# Altium schematic sheet Ctrl Sist de seguridad SCH.SchDoc
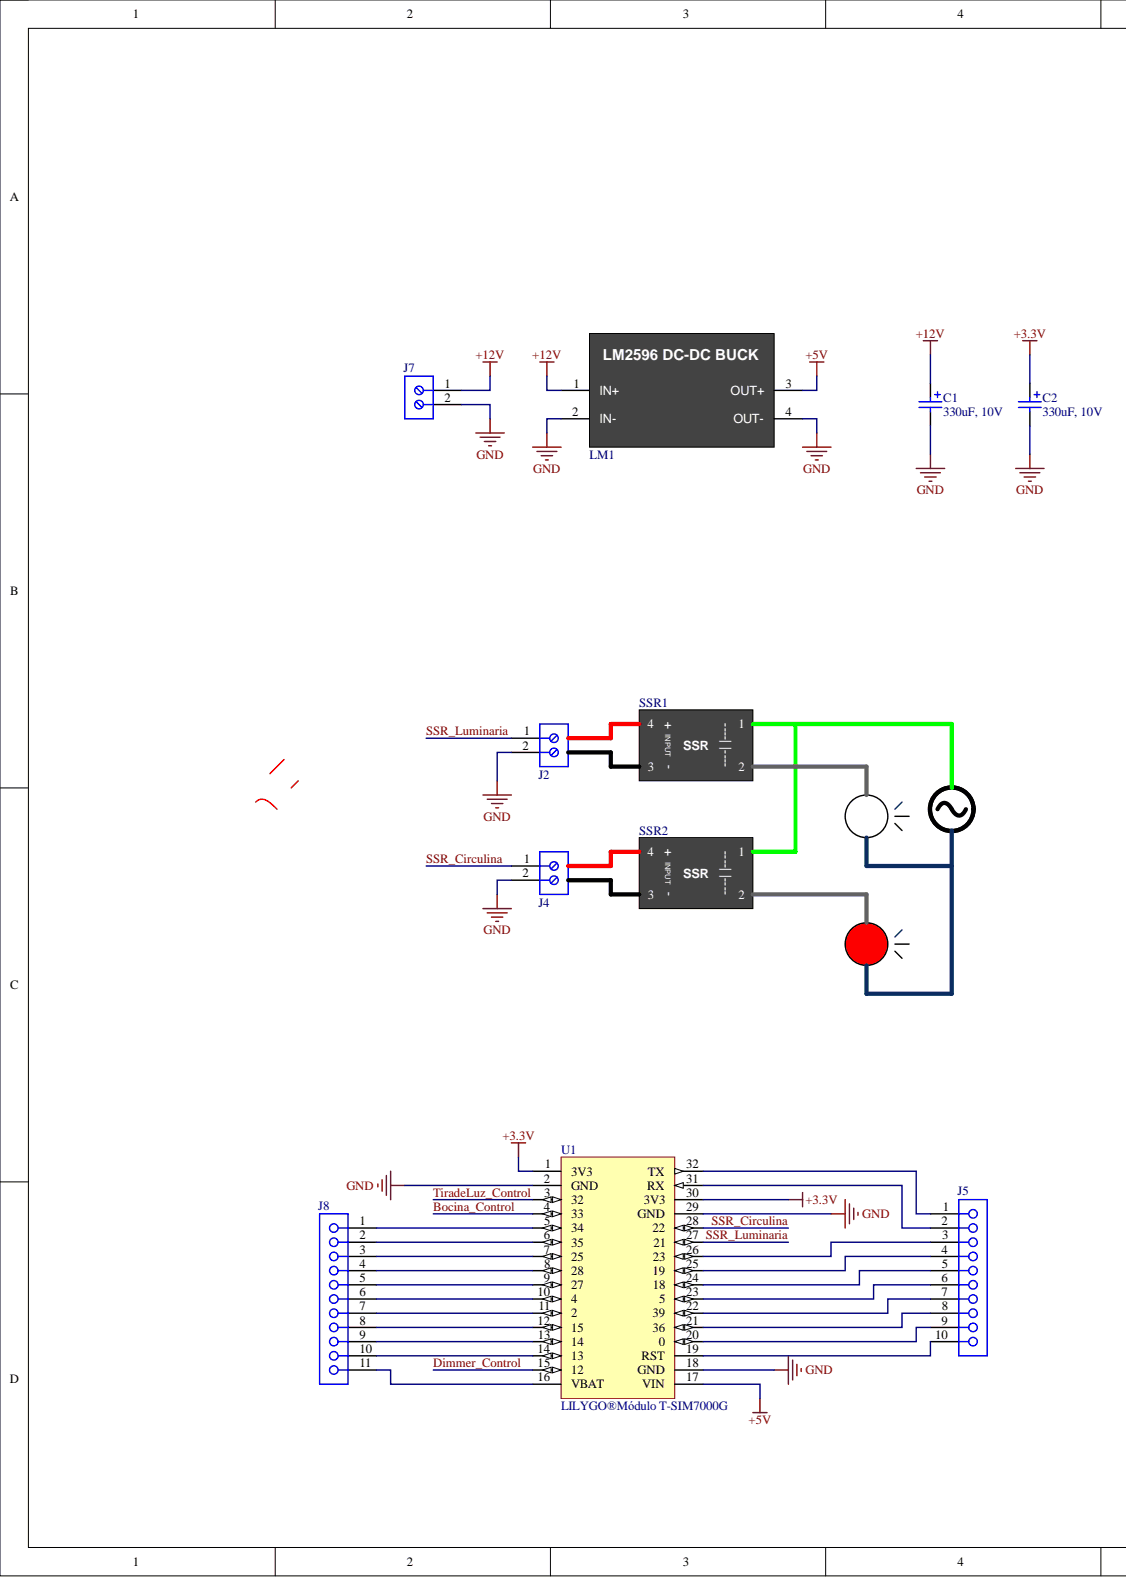
[diagram p0: Ctrl Sist de seguridad SCH.SchDoc, left]
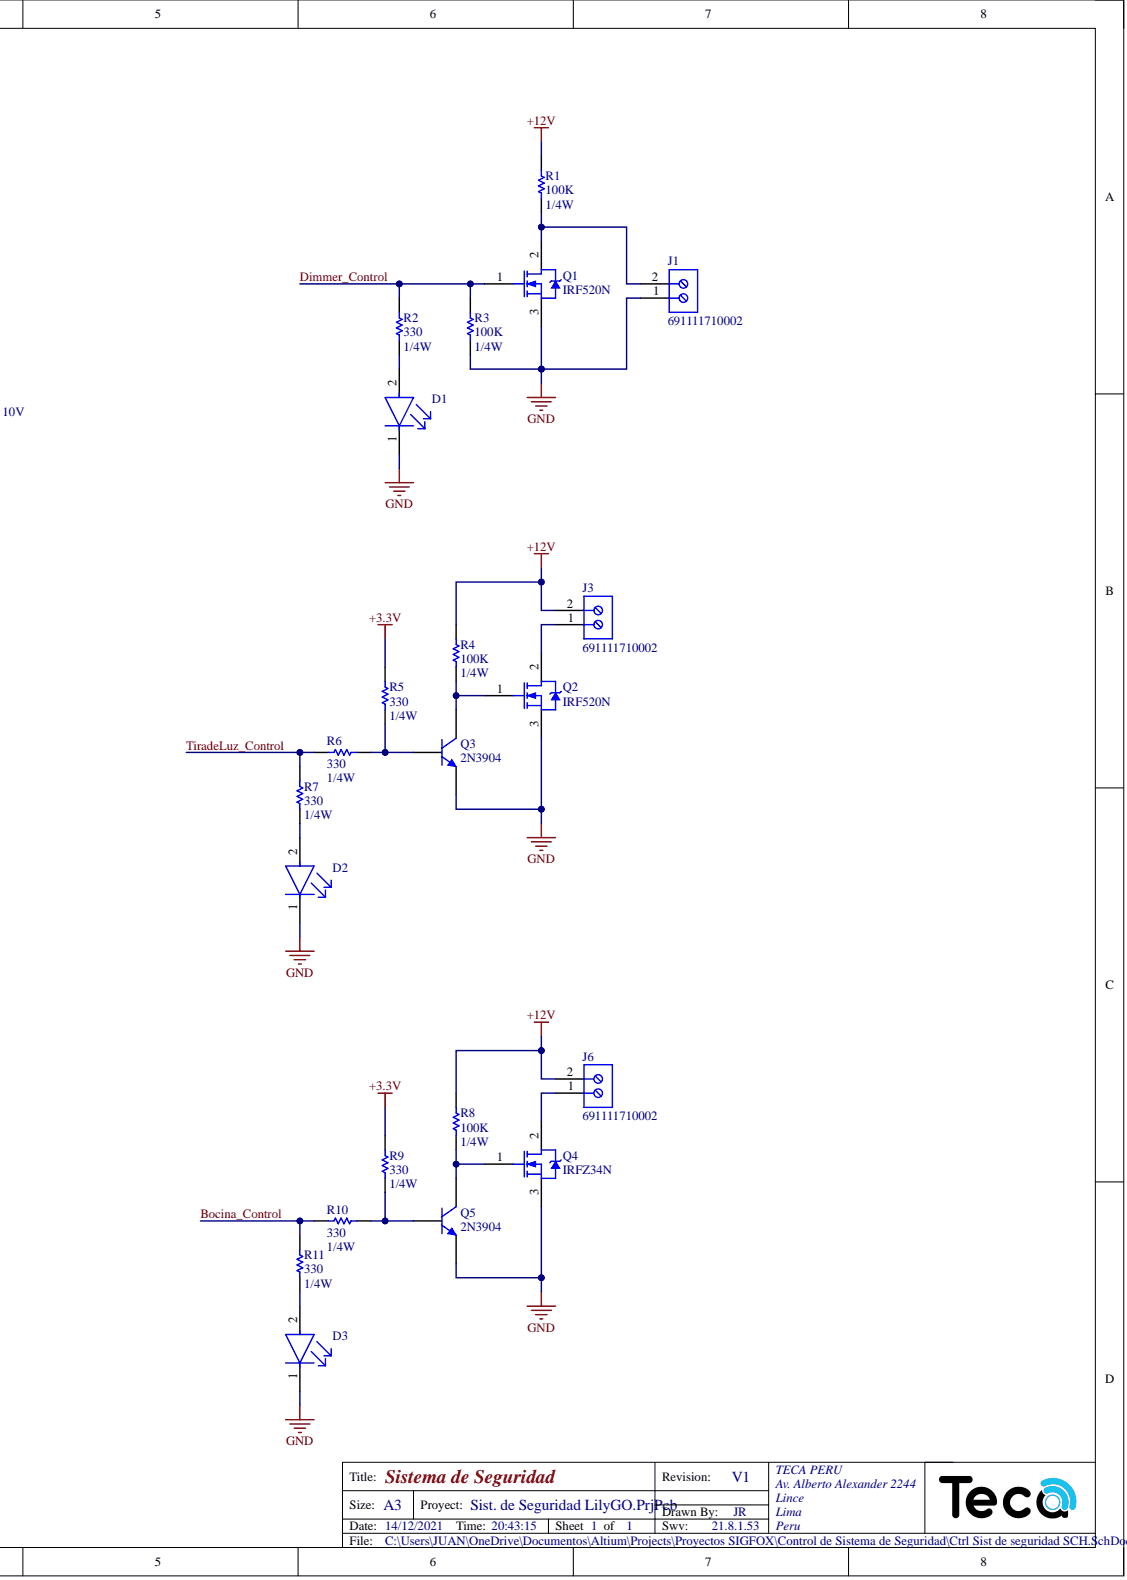
[diagram p1: Ctrl Sist de seguridad SCH.SchDoc, right]

## Parts
C1  330uF, 10V  [WCAP-ATLI_D8H11.5]
C2  330uF, 10V  [WCAP-ATLI_D8H11.5]
D1  HLMP-4700  [FP-HLMP-4700-MFG]  (value not drawn)
D2  HLMP-4700  [FP-HLMP-4700-MFG]  (value not drawn)
D3  HLMP-4700  [FP-HLMP-4700-MFG]  (value not drawn)
J1  691111710002  [691111710002]
J2  691111710002  [691111710002]
J3  691111710002  [691111710002]
J4  691111710002  [691111710002]
J5  61301011821  [61301011821]  (value not drawn)
J6  691111710002  [691111710002]
J7  691111710002  [691111710002]
J8  61301111821  [61301111821]  (value not drawn)
LM1  LM2596?  [LM2596]
Q1  IRF520N  [INTR-TO-220AB-3]
Q2  IRF520N  [INTR-TO-220AB-3]
Q3  2N3904  [TO-92A]
Q4  IRFZ34N  [INTR-TO-220AB-3]
Q5  2N3904  [TO-92A]
R1  Power Rating: 1/4W  Resistance: 100K  [RESISTOR 1/4W - 10mm]  (hidden comment: Resistor 1/4W)
R2  Power Rating: 1/4W  [RESISTOR 1/4W - 10mm]  (hidden comment: Resistor 1/4W)
R3  Power Rating: 1/4W  Resistance: 100K  [RESISTOR 1/4W - 10mm]  (hidden comment: Resistor 1/4W)
R4  Power Rating: 1/4W  Resistance: 100K  [RESISTOR 1/4W - 10mm]  (hidden comment: Resistor 1/4W)
R5  Power Rating: 1/4W  [RESISTOR 1/4W - 10mm]  (hidden comment: Resistor 1/4W)
R6  Resistor 1/4W  [RESISTOR 1/4W - 10mm]  (value not drawn)
R7  Power Rating: 1/4W  [RESISTOR 1/4W - 10mm]  (hidden comment: Resistor 1/4W)
R8  Power Rating: 1/4W  Resistance: 100K  [RESISTOR 1/4W - 10mm]  (hidden comment: Resistor 1/4W)
R9  Power Rating: 1/4W  [RESISTOR 1/4W - 10mm]  (hidden comment: Resistor 1/4W)
R10  Resistor 1/4W  [RESISTOR 1/4W - 10mm]  (value not drawn)
R11  Power Rating: 1/4W  [RESISTOR 1/4W - 10mm]  (hidden comment: Resistor 1/4W)
SSR1  SSR  [SSR Generic]
SSR2  SSR  [SSR Generic]
U1  LILYGO®Módulo T-SIM7000G  [LILYGO T-SIM7000G]

## Nets
+3.3V: C2.1 R5.2 R9.2 U1.1(3V3) U1.30(3V3)
+5V: LM1.3(OUT+) U1.17(VIN)
+12V: C1.1 J3.2 J6.2 J7.1 LM1.1(IN+) R1.2 R4.2 R8.2
GND: C1.2 C2.2 D1.1 D2.1 D3.1 J1.1 J2.2 J4.2 J7.2 LM1.2(IN-) LM1.4(OUT-) Q1.3 Q2.3 Q3.1 Q4.3 Q5.1 R3.1 U1.2(GND) U1.18(GND) U1.29(GND)
Bocina_Control: R10.2 R11.1 U1.4
Dimmer_Control: Q1.1 R2.2 R3.2 U1.15
SSR_Circulina: J4.1 U1.28
SSR_Luminaria: J2.1 U1.27
TiradeLuz_Control: R6.2 R7.1 U1.3
NetD1_2: D1.2 R2.1
NetD2_2: D2.2 R7.2
NetD3_2: D3.2 R11.2
NetJ1_2: J1.2 Q1.2 R1.1
NetJ3_1: J3.1 Q2.2
NetJ5_1: J5.1 U1.32(TX)
NetJ5_2: J5.2 U1.31(RX)
NetJ5_3: J5.3 U1.26
NetJ5_4: J5.4 U1.25
NetJ5_5: J5.5 U1.24
NetJ5_6: J5.6 U1.23
NetJ5_7: J5.7 U1.22
NetJ5_8: J5.8 U1.21
NetJ5_9: J5.9 U1.20
NetJ5_10: J5.10 U1.19(RST)
NetJ6_1: J6.1 Q4.2
NetJ8_1: J8.1 U1.5
NetJ8_2: J8.2 U1.6
NetJ8_3: J8.3 U1.7
NetJ8_4: J8.4 U1.8
NetJ8_5: J8.5 U1.9
NetJ8_6: J8.6 U1.10
NetJ8_7: J8.7 U1.11
NetJ8_8: J8.8 U1.12
NetJ8_9: J8.9 U1.13
NetJ8_10: J8.10 U1.14
NetJ8_11: J8.11 U1.16(VBAT)
NetQ2_1: Q2.1 Q3.3 R4.1
NetQ3_2: Q3.2 R5.1 R6.1
NetQ4_1: Q4.1 Q5.3 R8.1
NetQ5_2: Q5.2 R9.1 R10.1

## Library data of the parts
C1 C2 = 860080274009: WCAP-ATLI Aluminum Electrolytic Capacitor, Radial, THT, D8xH11.5mm, 330uF, 10V; Manufacturer: Wurth Elektronik; Capacitance Tolerance: +/-20%; Dissipation Factor: 14%; Endurance: 3000h; Leakage Current: 33uA; Rated Voltage: 10VDC; Ripple Current: 540mA; Technical Reference: ATEE115331M010DSPA7C000; Capacitance: 330uF; Component Kind: Standard; ComponentLink1Description: Manufacturer; ComponentLink1URL: http://www.we-online.de; ComponentLink2Description: Datasheet; ComponentLink2URL: https://www.we-online.com/catalog/datasheet/860080274009.pdf; Diameter: 8mm; Height: 11.5mm; Manufacturer Part Number: 860080274009; Mounting Technology: THT; Operating Temperature: -55 to +105degC; Packaging: Ammo Pack; Published Date: October 2020; Library Name: WCAP-ATLI Aluminion Electrolytic Capacitors.IntLib
D1-D3 = CMP-18399-000008-1: LED RED DIFFUSED T-1 3/4 T/H; Manufacturer: Broadcom; Forward Current: 2mA; Forward Voltage: 1.7V; Led Orientation: Straight; Luminous Intensity: 2.3mcd; Peak Emmision Wavelength: 635nm; Power Dissipation: 14mW; Reverse Voltage: 5V; Viewing Angle: 50°; Wavelength: 626nm; Automotive: No; Category: Diode; Colour: Red; Component Kind: Standard; ComponentLink2Description: Datasheet; ComponentLink2URL: https://docs.broadcom.com/docs/AV02-1557EN; Device Class L1: Optoelectronics; Device Class L2: LEDs; Device Class L3: unset; Digikey Description: LED RED DIFFUSED T-1 3/4 T/H; Lead Free: Yes; Library Name: Altium Content Vault; Manufacturer Part Number: HLMP-4700; Mouser Description: LED; Red; 2.3mcd; 0.24In.Dia.; T-1-3/4; 500mA; 1.7V; 635nm; 50deg; 5V; 24mW; -55degC;; Octopart: https://octopart.com/hlmp-4700-broadcom-71130526; Package: HLMP-4700; RoHS: TRUE; Temperature: -40°C to +100°C; Temperature Range High: +100°C; Temperature Range Low: -40°C
J1-J4 J6 J7 = 691111710002: WR-TBL Serie 111 Horizontal Entry Modular, Pressure Clamp, THT, pitch 5mm, 2p; Manufacturer: Wurth Elektronik; Modularity: Yes; PCB/Cable/Panel: PCB; Pin Number: 2; Rated Current [UL]: 15A; Working Voltage [UL]: 250VAC; Application: Pressure Clamp; Component Kind: Standard; ComponentLink1Description: Manufacturer; ComponentLink1URL: http://www.we-online.de; ComponentLink2Description: Datasheet; ComponentLink2URL: https://katalog.we-online.de/em/datasheet/691111710002.pdf; Manufacturer Part Number: 691111710002; Mounting Technology: THT; Operating Temperature: -40 to +105degC; Packaging: Box; Pitch: 5mm; Published Date: Feburary 2021; Type: Horizontal; Library Name: WR-TBL Terminal Blocks.IntLib
J5 = 61301011821: WR-PHD Socket Header, THT, pitch 2.54mm, Single Row, Vertical, 10p; Manufacturer: Wurth Elektronik; Contact Resistance: 20mOhm; Pin Number: 10; Rated Current: 3A; Withstanding Voltage: 500VAC; Working Voltage: 250VAC; Component Kind: Standard; ComponentLink1Description: Manufacturer; ComponentLink1URL: http://www.we-online.de; ComponentLink2Description: Datasheet; ComponentLink2URL: https://www.we-online.com/catalog/datasheet/61301011821.pdf; Gender: Socket Header; Manufacturer Part Number: 61301011821; Mounting Technology: THT; Operating Temperature: -40 to +125degC; Orientation: Vertical; Packaging: Tray; Pitch: 2.54mm; Published Date: May 2020; Row Number: Single; Library Name: WE-WR PHD Conectors.IntLib
J8 = 61301111821: WR-PHD Socket Header, THT, pitch 2.54mm, Single Row, Vertical, 11p; Manufacturer: Wurth Elektronik; Contact Resistance: 20mOhm; Pin Number: 11; Rated Current: 3A; Withstanding Voltage: 500VAC; Working Voltage: 250VAC; Component Kind: Standard; ComponentLink1Description: Manufacturer; ComponentLink1URL: http://www.we-online.de; ComponentLink2Description: Datasheet; ComponentLink2URL: https://www.we-online.com/catalog/datasheet/61301111821.pdf; Gender: Socket Header; Manufacturer Part Number: 61301111821; Mounting Technology: THT; Operating Temperature: -40 to +125degC; Orientation: Vertical; Packaging: Tray; Pitch: 2.54mm; Published Date: May 2020; Row Number: Single; Library Name: WE-WR PHD Conectors.IntLib
LM1 = LM2596?: Módulo de DC-DC LM2596, regulador de convertidor Buck de reducción ajustable, VIN: 3,2 V-40V ; VOUT: 1,25 V-35V; Component Kind: Standard; Library Name: Modulos.IntLib
Q1 Q2 Q4 = CMP-2100-03647-1: HEXFET(R) Power MOSFET, 100 V, 9.7 A, 0.2 Ohm, -55 to 175 degC, 3-Pin FM (TO-220AB), RoHS, Tube; Manufacturer: International Rectifier; Continuous Drain Current (ID): 9.7A; Drain to Source Breakdown Voltage: 100V; Drain to Source Resistance: 200mOhm; Element Configuration: Single; Fall Time: 23ns; Gate to Source Voltage (Vgs): 20V; Input Capacitance: 330pF; Max Power Dissipation: 48W; Number of Elements: 1; Turn-Off Delay Time: 32ns; Turn-On Delay Time: 4.5ns; Case/Package: TO-220AB; Component Kind: Standard; Current Rating: 9.7A; Datasheet URL: http://www.infineon.com/dgdl/irf520npbf.pdf?fileId=5546d462533600a4015355e340711985; Datasheet Version: 37926.0; Drain to Source Voltage (Vdss): 100V; Height: 15.24mm; Lead Free: LeadFree; Lead Pitch: 2.54mm; Length: 10.54mm; Library Name: Altium Content Vault; Manufacturer URL: http://internationalrectifier.com/; Max Operating Temperature: 175degC; Min Operating Temperature: -55degC; Mount: ThroughHole; Number of Pins: 3; Package Description: 3-Pin Flange Mount, Body 4.445 x 10.415 mm, Pitch 2.54 mm; Package Quantity: 50; Package Reference: TO-220AB; Packaging: Rail/Tube; Power Dissipation: 48W; Radiation Hardening: No; Rds On Max: 200mOhm; REACH SVHC: NoSVHC; Rise Time: 23ns; RoHSCompliant: true; Voltage Rating (DC): 100V; Width: 4.69mm
Q3 Q5 = 2N3904: NPN General Purpose Amplifier; Component Kind: Standard; ComponentLink1Description: Manufacturer Link; ComponentLink1URL: http://www.fairchildsemi.com/; ComponentLink2Description: Datasheet; ComponentLink2URL: http://www.fairchildsemi.com/ds/2N%2F2N3904.pdf; DatasheetVersion: 1997; LatestRevisionDate: 15-Jan-2003; LatestRevisionNote: PCB Footprint 'BCY-W3/D4.7' replaced; Library Name: Miscellaneous Devices.IntLib; PackageDescription: TO, Flat Index; 3 In-Line, Axial Leads; Body Dia. 4.5mm; Leads 0.51 x 0.48 mm (max); PackageReference: TO-92A; PackageVersion: Sep-1998; Published: 8-Jun-2000; Signal Integrity: 2N3904; Simulation: 2N3904; Publisher: Altium Limited
R1 R3 R4 R8 = Resistor 1/4W: Tolerance: 1%; Case/Package: Axial; Category: Through-Hole Resistors; Component Kind: Standard; Length: 6.3mm; Mount: Through Hole; Library Name: Resistencias THT.IntLib
R2 R5-R7 R9-R11 = Resistor 1/4W: Resistance: 330; Tolerance: 1%; Case/Package: Axial; Category: Through-Hole Resistors; Component Kind: Standard; Length: 6.3mm; Mount: Through Hole; Library Name: Resistencias THT.IntLib
  R6 R10: Power Rating: 1/4W
U1 = LILYGO®Módulo T-SIM7000G: LILYGO®Módulo de T-SIM7000G TTGO, Chip de ESP32-WROVER-B, WiFi, Bluetooth 18650, soporte de batería, placa de carga Solar; Component Kind: Standard; Library Name: Modulos.IntLib
SSR1 SSR2 = SSR: Component Kind: Standard; Library Name: Modulos.IntLib
